# Altium schematic sheet FPGA.SchDoc
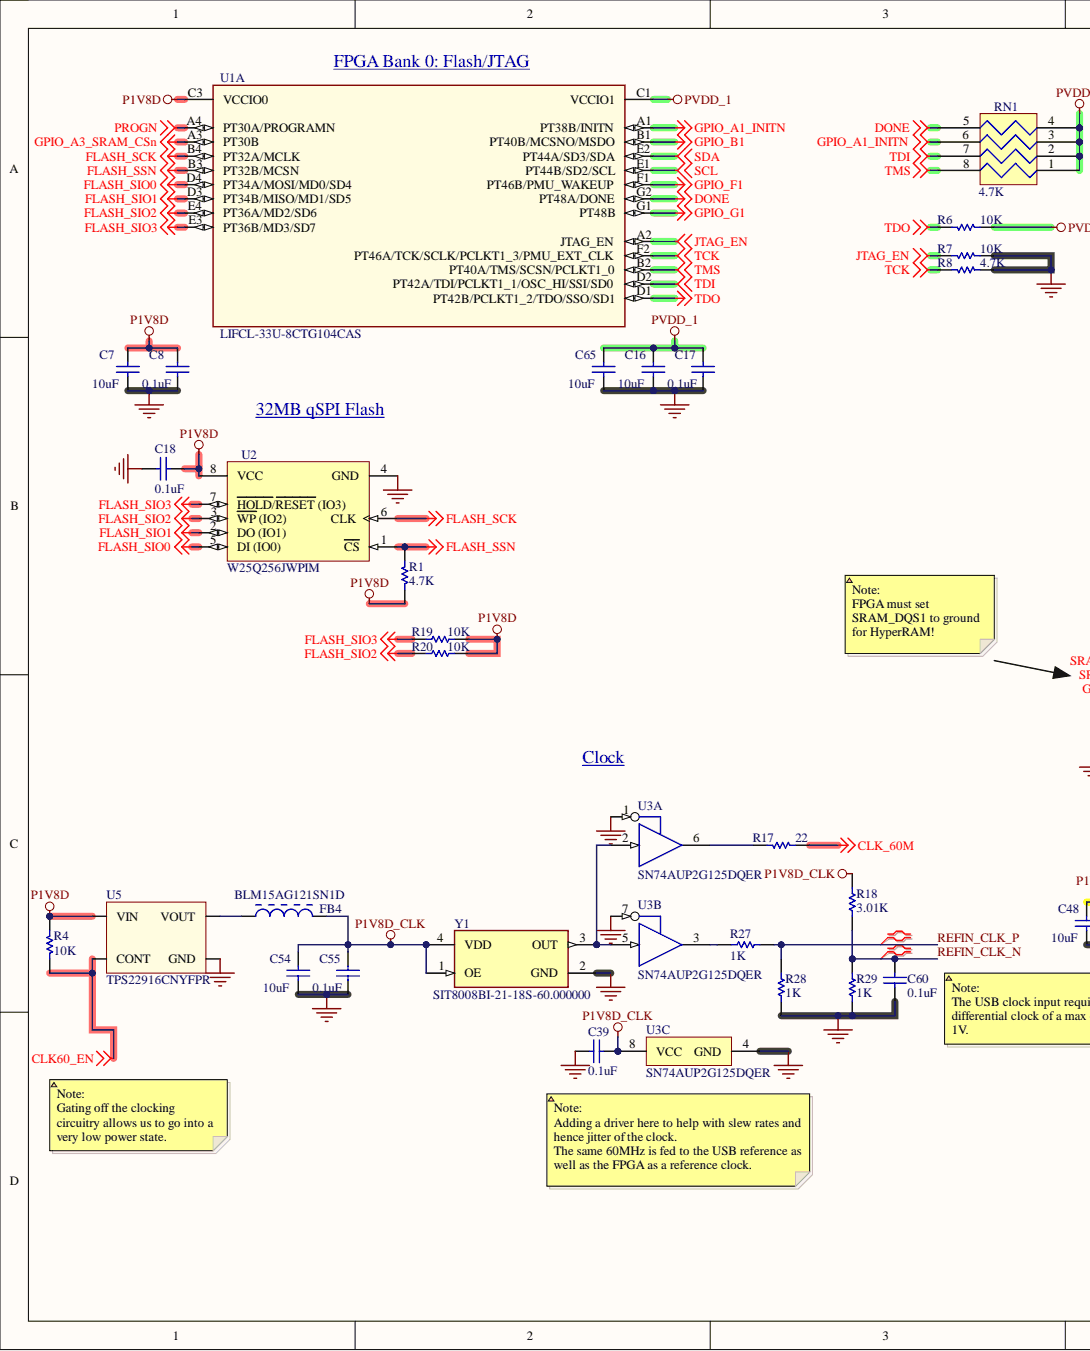
[diagram p0: FPGA.SchDoc, left]
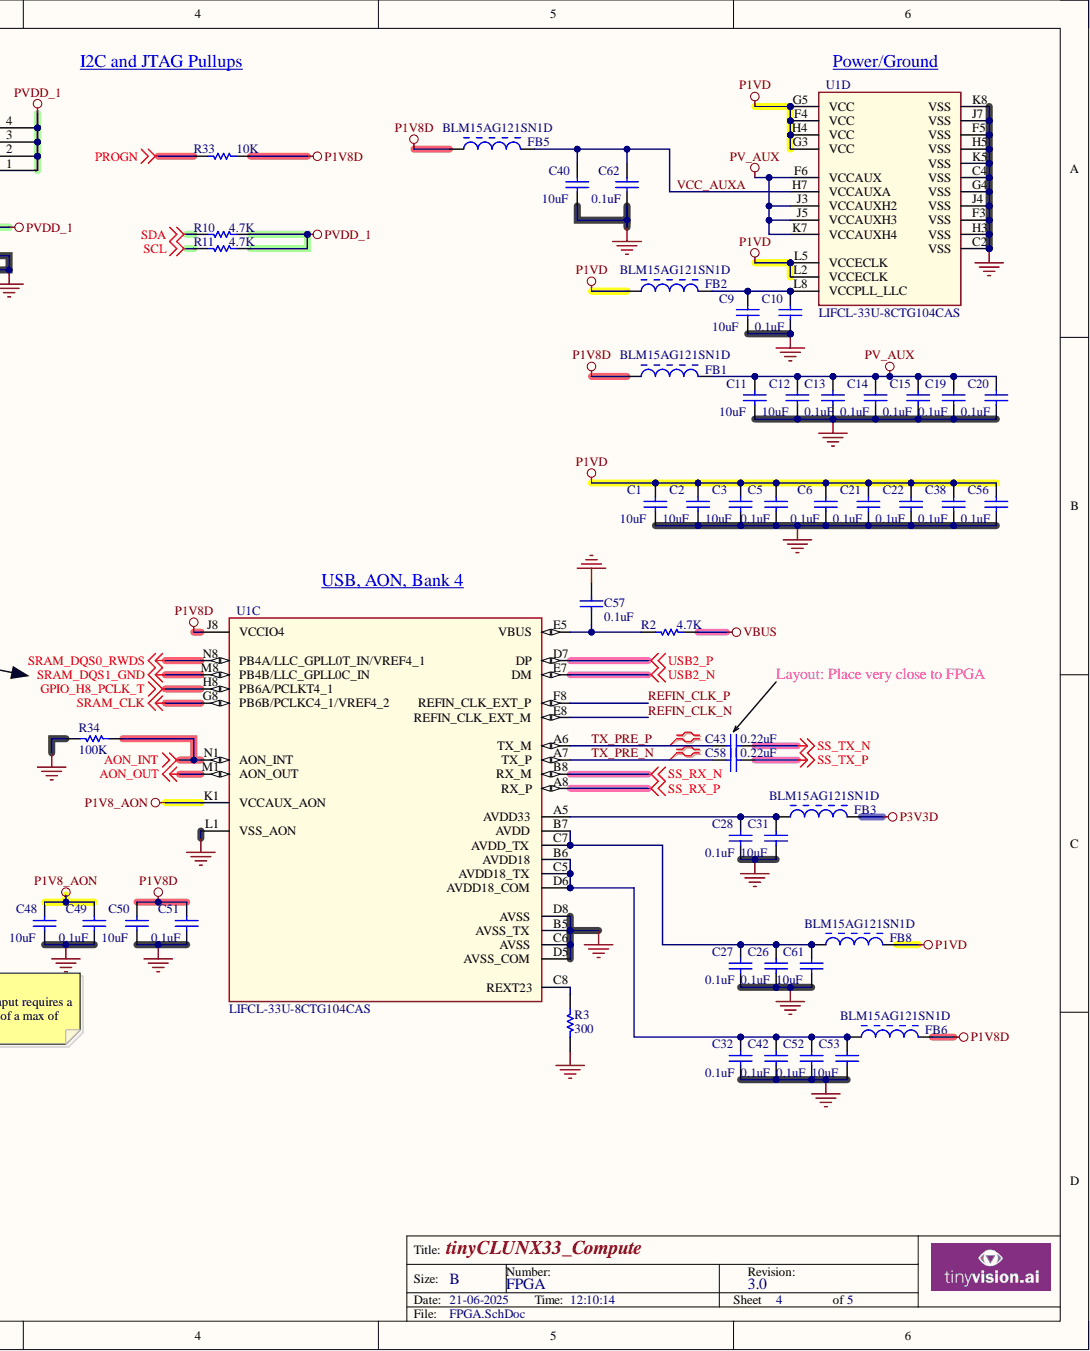
[diagram p1: FPGA.SchDoc, right]

## Parts
C1  10uF  [CAPC1005X55USR]
C2  10uF  [CAPC1005X55USR]
C3  10uF  [CAPC1005X55USR]
C5  0.1uF  [CAPC0603X33N]
C6  0.1uF  [CAPC0603X33N]
C7  10uF  [CAPC1005X55USR]
C8  0.1uF  [CAPC0603X33N]
C9  10uF  [CAPC1005X55USR]
C10  0.1uF  [CAPC0603X33N]
C11  10uF  [CAPC1005X55USR]
C12  10uF  [CAPC1005X55USR]
C13  0.1uF  [CAPC0603X33N]
C14  0.1uF  [CAPC0603X33N]
C15  0.1uF  [CAPC0603X33N]
C16  10uF  [CAPC1005X55USR]
C17  0.1uF  [CAPC0603X33N]
C18  0.1uF  [CAPC0603X33N]
C19  0.1uF  [CAPC0603X33N]
C20  0.1uF  [CAPC0603X33N]
C21  0.1uF  [CAPC0603X33N]
C22  0.1uF  [CAPC0603X33N]
C26  0.1uF  [CAPC0603X33N]
C27  0.1uF  [CAPC0603X33N]
C28  0.1uF  [CAPC0603X33N]
C31  10uF  [CAPC1005X55USR]
C32  0.1uF  [CAPC0603X33N]
C38  0.1uF  [CAPC0603X33N]
C39  0.1uF  [CAPC1005X55USR]
C40  10uF  [CAPC1005X55USR]
C42  0.1uF  [CAPC0603X33N]
C43  0.22uF  [CAPC0603X33N]
C48  10uF  [CAPC1005X55USR]
C49  0.1uF  [CAPC0603X33N]
C50  10uF  [CAPC1005X55USR]
C51  0.1uF  [CAPC0603X33N]
C52  0.1uF  [CAPC0603X33N]
C53  10uF  [CAPC1005X55USR]
C54  10uF  [CAPC1005X55USR]
C55  0.1uF  [CAPC0603X33N]
C56  0.1uF  [CAPC0603X33N]
C57  0.1uF  [CAPC0603X33N]
C58  0.22uF  [CAPC0603X33N]
C60  0.1uF  [CAPC0603X33N]
C61  10uF  [CAPC1005X55USR]
C62  0.1uF  [CAPC0603X33N]
C65  10uF  [CAPC1005X55USR]
FB1  BLM15AG121SN1D  [0402_FB]
FB2  BLM15AG121SN1D  [0402_FB]
FB3  BLM15AG121SN1D  [0402_FB]
FB4  BLM15AG121SN1D  [0402_FB]
FB5  BLM15AG121SN1D  [0402_FB]
FB6  BLM15AG121SN1D  [0402_FB]
FB8  BLM15AG121SN1D  [0402_FB]
R1  4.7K  [RESC1005X40USR]
R2  4.7K  [RESC1005X40USR]
R3  300  [RESC1005X40USR]
R4  10K  [RESC1005X40USR]
R6  10K  [RESC1005X40USR]
R7  10K  [RESC1005X40USR]
R8  4.7K  [RESC1005X40USR]
R10  4.7K  [RESC1005X40USR]
R11  4.7K  [RESC1005X40USR]
R17  22  [RESC1005X40USR]
R18  3.01K  [RESC1005X40USR]
R19  10K  [RESC1005X40USR]
R20  10K  [RESC1005X40USR]
R27  1K  [RESC1005X40USR]
R28  1K  [RESC1005X40USR]
R29  1K  [RESC1005X40USR]
R33  10K  [RESC1005X40USR]
R34  100K  [RESC1005X40USR]
RN1  4.7K  [RESCAXS50P100X200X45-8NA]
U1  LIFCL-33U-8CTG104CAS  [BGA104C65P8X13_550X850X100]  (parts A,C,D)
U2  W25Q256JWPIM  [WSON-8(6X5mm)]
U3  SN74AUP2G125DQER  [DQE0008A_V]  (parts A,B,C)
U5  TPS22916CNYFPR  [WCSP4D]
Y1  SIT8008BI-21-18S-60.000000  [XTAL_32X25X08_SIT8008A]

## Nets
AON_INT: R34.1 U1.N1(AON_INT)
AON_OUT: U1.M1(AON_OUT)
CLK60_EN: R4.1 U5.B2(CONT)
CLK_60M: R17.1
DONE: RN1.5 U1.G2(PT48A/DONE)
FLASH_SCK: U1.B4(PT32A/MCLK) U2.6(CLK)
FLASH_SIO0: U1.D4(PT34A/MOSI/MD0/SD4) U2.5(DI_(IO0))
FLASH_SIO1: U1.D3(PT34B/MISO/MD1/SD5) U2.2(DO_(IO1))
FLASH_SIO2: R20.2 U1.E4(PT36A/MD2/SD6) U2.3(WP_(IO2))
FLASH_SIO3: R19.2 U1.E3(PT36B/MD3/SD7) U2.7(HOLD/RESET_(IO3))
FLASH_SSN: R1.2 U1.B3(PT32B/MCSN) U2.1(CS)
GND: C1.1 C2.1 C3.1 C5.1 C6.1 C7.1 C8.1 C9.1 C10.1 C11.1 C12.1 C13.1 C14.1 C15.1 C16.1 C17.1 C18.1 C19.1 C20.1 C21.1 C22.1 C26.1 C27.1 C28.1 C31.1 C32.1 C38.1 C39.2 C40.1 C42.1 C48.1 C49.1 C50.1 C51.1 C52.1 C53.1 C54.1 C55.1 C56.1 C57.1 C60.1 C61.1 C62.1 C65.1 R3.1 R7.1 R8.2 R28.2 R29.2 R34.2 U1.B5(AVSS_TX) U1.C2(VSS) U1.C4(VSS) U1.C6(AVSS) U1.D5(AVSS_COM) U1.D8(AVSS) U1.F3(VSS) U1.F5(VSS) U1.G4(VSS) U1.H3(VSS) U1.H5(VSS) U1.J4(VSS) U1.J7(VSS) U1.K5(VSS) U1.K8(VSS) U1.L1(VSS_AON) U2.4(GND) U3.1 U3.4(GND) U3.7 U5.B1(GND) Y1.2(GND)
GPIO_A1_INITN: RN1.6 U1.A1(PT38B/INITN)
GPIO_A3_SRAM_CSn: U1.A3(PT30B)
GPIO_B1: U1.B1(PT40B/MCSNO/MSDO)
GPIO_F1: U1.F1(PT46B/PMU_WAKEUP)
GPIO_G1: U1.G1(PT48B)
GPIO_H8_PCLK_T: U1.H8(PB6A/PCLKT4_1)
JTAG_EN: R7.2 U1.A2(JTAG_EN)
P1V8_AON: C48.2 C49.2 U1.K1(VCCAUX_AON)
P1V8D: C7.2 C8.2 C18.2 C50.2 C51.2 FB1.1 FB5.1 FB6.2 R1.1 R4.2 R19.1 R20.1 R33.1 U1.C3(VCCIO0) U1.J8(VCCIO4) U2.8(VCC) U5.A2(VIN)
P1V8D_CLK: C39.1 C54.2 C55.2 FB4.2 R18.1 U3.8(VCC) Y1.1(OE) Y1.4(VDD)
P1VD: C1.2 C2.2 C3.2 C5.2 C6.2 C21.2 C22.2 C38.2 C56.2 FB2.1 FB8.2 U1.F4(VCC) U1.G3(VCC) U1.G5(VCC) U1.H4(VCC) U1.L2(VCCECLK) U1.L5(VCCECLK)
P3V3D: FB3.2
PROGN: R33.2 U1.A4(PT30A/PROGRAMN)
PV_AUX: C11.2 C12.2 C13.2 C14.2 C15.2 C19.2 C20.2 FB1.2 U1.F6(VCCAUX) U1.J3(VCCAUXH2) U1.J5(VCCAUXH3) U1.K7(VCCAUXH4)
PVDD_1: C16.2 C17.2 C65.2 R6.1 R10.2 R11.2 RN1.1 RN1.2 RN1.3 RN1.4 U1.C1(VCCIO1)
SCL: R11.1 U1.E1(PT44B/SD2/SCL)
SDA: R10.1 U1.E2(PT44A/SD3/SDA)
SRAM_CLK: U1.G8(PB6B/PCLKC4_1/VREF4_2)
SRAM_DQS0_RWDS: U1.N8(PB4A/LLC_GPLL0T_IN/VREF4_1)
SRAM_DQS1_GND: U1.M8(PB4B/LLC_GPLL0C_IN)
SS_RX_N: U1.B8(RX_M)
SS_RX_P: U1.A8(RX_P)
SS_TX_N: C43.1
SS_TX_P: C58.1
TCK: R8.1 U1.F2(PT46A/TCK/SCLK/PCLKT1_3/PMU_EXT_CLK)
TDI: RN1.7 U1.D2(PT42A/TDI/PCLKT1_1/OSC_HI/SSI/SD0)
TDO: R6.2 U1.D1(PT42B/PCLKT1_2/TDO/SSO/SD1)
TMS: RN1.8 U1.B2(PT40A/TMS/SCSN/PCLKT1_0)
USB2_N: U1.E7(DM)
USB2_P: U1.D7(DP)
VBUS: R2.2
REFIN_CLK_N: C60.2 R18.2 R29.1 U1.E8(REFIN_CLK_EXT_M)
REFIN_CLK_P: R27.2 R28.1 U1.F8(REFIN_CLK_EXT_P)
TX_PRE_N: C58.2 U1.A7(TX_P)
TX_PRE_P: C43.2 U1.A6(TX_M)
VCC_AUXA: C40.2 C62.2 FB5.2 U1.H7(VCCAUXA)
NetC9_2: C9.2 C10.2 FB2.2 U1.L8(VCCPLL_LLC)
NetC26_2: C26.2 C27.2 C61.2 FB8.1 U1.B7(AVDD) U1.C7(AVDD_TX)
NetC28_2: C28.2 C31.2 FB3.1 U1.A5(AVDD33)
NetC32_2: C32.2 C42.2 C52.2 C53.2 FB6.1 U1.B6(AVDD18) U1.C5(AVDD18_TX) U1.D6(AVDD18_COM)
NetC57_2: C57.2 R2.1 U1.E5(VBUS)
NetFB4_1: FB4.1 U5.A1(VOUT)
NetR3_2: R3.2 U1.C8(REXT23)
NetR17_2: R17.2 U3.6
NetR27_1: R27.1 U3.3
NetU3_2: U3.2 U3.5 Y1.3(OUT)

## Library data of the parts
C1-C3 C7 C9 C11 C12 C16 C31 C40 C48 C50 C53 C54 C61 C65 = Cap_10uF_X5R_20%_10V_0402: CAP CER 10UF 10V X5R 0402; Manufacturer: Samsung Electro-Mechanics; Manufacturer Part Number: CL05A106MP5NUNC; Part #: E-CAP-042; Component Kind: Standard; ComponentLink1Description: New link; Height: 0.55mm; Package: 0402; Supplier 1: Digi-Key; Supplier Part Number 1: 1276-1450-1-ND; value: 10uF; Library Name: tinyCLUNX33_Compute.SCHLIB
C5 C6 C8 C10 C13-C15 C17-C22 C26-C28 C32 C38 C42 C49 C51 C52 C55-C57 C60 C62 = CAP CER 0.1UF 10V X7S 0201: CAP CER 0.1UF 10V X5R 0201; Manufacturer: Murata Electronics; Manufacturer Part Number: GRM033R61A104KE15D; Component Kind: Standard; Height: 0.33mm; Library Name: Smart Camera v2.SCHLIB; Package: 0201; Supplier 1: Digi-Key; Supplier Part Number 1: 490-5881-1-ND; value: 0.1uF
C39 = CAP CER 0.1UF 16V X7R 0402: Manufacturer: TDK Corporation; Manufacturer Part Number: CGA2B1X7R1C104K050BC; Component Kind: Standard; Description: CAP CER 0.1UF 16V X7R 0402; Height: 0.55mm; Library Name: NX Module baseboard.SCHLIB; Package: 0402; Supplier 1: Digi-Key; Supplier Part Number 1: 445-5613-2-ND; value: 0.1uF
C43 C58 = CAP CER 0.22UF 10V X5R 0201: Manufacturer: TDK Corporation; Manufacturer Part Number: C0603X5R1A224K030BB; Component Kind: Standard; Description: CAP CER 0.22UF 10V X5R 0201; Height: 0.33mm; Library Name: MainLIB.SCHLIB; Package: 0201; Supplier 1: Digi-Key; Supplier Part Number 1: 445-7320-2-ND; value: 0.22uF
FB1-FB6 FB8 = MURA-FB-2: FERRITE BEAD 120 OHM 0402 1LN; Manufacturer: Murata Electronics; Value: 120Ohms; Component Kind: Standard; ComponentLink1Description: New link; Height: 0.55mm; Library Name: Smart Camera.SCHLIB; Manufacturer Part Number: BLM15AG121SN1D; Package: 0402; Supplier 1: Digi-Key; Supplier Part Number 1: 490-1005-1-ND
R1 R2 R8 R10 R11 = RES 4.7K OHM 1% 1/20W 0201: RES 4.7K OHM 1% 1/16W 0402; Manufacturer: Yageo; Manufacturer Part Number: RC0402FR-074K7L; Component Kind: Standard; Height: 0.4mm; Library Name: Smart Camera v2.SCHLIB; Package: 0402; Supplier 1: Digi-Key; Supplier Part Number 1: 311-4.7KLRCT-ND; value: 4.7K
R3 = RES 4.7K OHM 1% 1/20W 0201: RES 300 OHM 1% 1/16W 0402; Manufacturer: Yageo; Manufacturer Part Number: RC0402FR-07300RL; Component Kind: Standard; Height: 0.4mm; Library Name: Smart Camera v2.SCHLIB; Package: 0402; Supplier 1: Digi-Key; Supplier Part Number 1: 311-300LRCT-ND; value: 300
R4 R6 R7 R19 R20 R33 = Res_10K_1%_0402: RES 10K OHM 1% 1/16W 0402; Manufacturer: Yageo; Manufacturer Part Number: RC0402FR-0710KL; Component Kind: Standard; Height: 0.4mm; Package: 0402; Supplier 1: Digi-Key; Supplier Part Number 1: 311-10.0KLRCT-ND; value: 10K; Library Name: MCD_Temp_LBE.SchLib
R17 = RES 22 OHM 1% 1/16W 0402: Manufacturer: Yageo; Manufacturer Part Number: RC0402FR-0722RL; Component Kind: Standard; Description: RES 22 OHM 1% 1/16W 0402; Height: 0.40mm; Library Name: NX Module baseboard.SCHLIB; Package: 0402; Supplier 1: Digi-Key; Supplier Part Number 1: 311-22.0LRCT-ND; value: 22
R18 = RES 3.01K OHM 1% 1/16W 0402: Manufacturer: Yageo; Manufacturer Part Number: RC0402FR-073K01L; Component Kind: Standard; Description: RES 3.01K OHM 1% 1/16W 0402; Height: 0.4mm; Library Name: MainLIB.SCHLIB; Package: 0402; Supplier 1: Digi-Key; Supplier Part Number 1: 311-3.01KLRCT-ND; value: 3.01K
R27-R29 = RES 1K OHM 1% 1/16W 0402: RES SMD 1K OHM 1% 1/16W 0402; Manufacturer: YAGEO; Manufacturer Part Number: RC0402FR-071KL; Component Kind: Standard; Height: 0.4mm; Package: 0402; Supplier 1: Digi-Key; Supplier Part Number 1: 311-1.00KLRCT-ND; value: 1K; Library Name: Tiger_Ti180.SCHLIB
R34 = Res_10K_1%_0402: RES 100K OHM 1% 1/16W 0402; Manufacturer: Yageo; Manufacturer Part Number: RC0402FR-07100KL; Component Kind: Standard; Height: 0.4mm; Package: 0402; Supplier 1: Digi-Key; Supplier Part Number 1: 311-100KLRCT-ND; value: 100K; Library Name: MCD_Temp_LBE.SchLib
RN1 = RES ARRAY 4 RES 47K OHM 0804: Res Thick Film Array 4.7K Ohm 5% ±200ppm/°C ISOL 8-Pin 0804(4 X 0402) Convex SMD Automotive T/R; Manufacturer: Panasonic; Manufacturer Part Number: EXB-28V472JX; Circuit Type: Isolated; Number of Contacts: 8; Number of Elements: 4; Power Dissipation: 63mW; Power Per Element: 62.5mW; Voltage Rating: 50V; Voltage Rating (DC): 100V; Case Code (Imperial): 0402; Case Code (Metric): 1005; Case/Package: 0804; Category: Resistor Arrays; Component Kind: Standard; ComponentLink1Description: https://datasheet.ciiva.com/46387/1480003-46387949.pdf?src-supplier=Element14; ComponentLink1URL: https://datasheet.ciiva.com/46387/1480003-46387949.pdf?src-supplier=Element14; ComponentLink2Description: https://datasheet.ciiva.com/46376/1480003-46376265.pdf?src-supplier=Element14; ComponentLink2URL: https://datasheet.ciiva.com/46376/1480003-46376265.pdf?src-supplier=Element14; ComponentLink3Description: https://datasheet.ciiva.com/28418/1790599-28418558.pdf?src-supplier=Element14; ComponentLink3URL: https://datasheet.ciiva.com/28418/1790599-28418558.pdf?src-supplier=Element14; ComponentLink4Description: https://datasheet.ciiva.com/28636/1790599-28636885.pdf?src-supplier=Element14; ComponentLink4URL: https://datasheet.ciiva.com/28636/1790599-28636885.pdf?src-supplier=Element14; ComponentLink5Description: https://datasheet.ciiva.com/30209/aoc0000ce1-30209125.pdf?src-supplier=Onlinecomponents.com; ComponentLink5URL: https://datasheet.ciiva.com/30209/aoc0000ce1-30209125.pdf?src-supplier=Onlinecomponents.com; Depth: 1mm; Height: 0.35mm; Lead Free: Lead Free; Length: 2mm; Library Name: NX Module.SCHLIB; Max Operating Temperature: 125°C; Military Standard: Not; Min Operating Temperature: -55°C; Mounting Technology: Surface Mount; Number of Resistors: 4; Package Quantity: 1; Packaging: Tape and Reel; Pins: 8; Pricing: 1=0.10000, 10=0.08100, 25=0.05640, 50=0.04380, 100=0.03130, 250=0.02444, 500=0.01942, 1000=0.01378, 5000=0.01065 (USD); Radiation Hardening: No; Reach SVHC Compliant: Unknown; Resistance Tolerance: 5%; RoHS: Yes; RoHS Compliant: Yes; Series: EXB; Stock: 1570078; Supplier 1: Digi-Key; Supplier Part Number 1: Y7472CT-ND; Technology: THICK FILM; Temperature Coefficient: 200ppm/°C; Terminal Pitch: 0.5mm; Termination: Convex; Tolerance: 5%; Value: 4.7K; Width: 1mm
U1 = LIFCL-33U-8CTG104CAS: Manufacturer: LATTICE; Component Kind: Standard; Library Name: NX Module.SCHLIB; Manufacturer Part Number: LIFCL-33U-8CTG104CAS
U2 = WINB-W25Q128FVSIG-SOIC-8: IC FLASH 256MBIT SPI/QUAD 8WSON; Manufacturer: Winbond; Category: Memory; Component Kind: Standard; Library Name: NX Module.SCHLIB; Manufacturer Part Number: W25Q256JWPIM; Pricing: 100=2.52 (USD); RoHS: Yes; Supplier 1: Digi-Key; Supplier Part Number 1: 256-W25Q256JWPIM-ND
U3 = CMP-0859-00754-3: Low-Power Dual Bus Buffer Gate With 3-State Outputs, DQE0008A, LARGE T&R; Manufacturer: Texas Instruments; 3-State Output: Yes; Bits(#): 2; F @ Nom Voltage(Max)(Mhz): 100; ICC @ Nom Voltage(Max)(mA): 0.0009; Input Type: CMOS; Logic: True; Output Drive (IOL/IOH)(Max)(mA): 20/-20; Rating: Catalog; Schmitt Trigger: No; Sub-Family: Non-Inverting Buffer/Driver; Technology Family: AUP; tpd @ Nom Voltage(Max)(ns): 37.9,30.2,17.2,13.0,8.3,6.5; VCC(Max)(V): 3.6; VCC(Min)(V): 0.8; Voltage(Nom)(V): 0.8,1.2,1.5,1.8,2.5,3.3; Component Kind: Standard; DatasheetVersion: SCES688D; Generic Part Number: SN74AUP2G125; Library Name: Altium Content Vault; Operating Temperature Range(C): -40 to 85; Output Type: CMOS; PackageReference: DQE0008A
U5 = TCK106AG: IC PWR SWITCH P-CHAN 1:1 4WCSPD; Manufacturer: Texas Instruments; 1pc cost: $0.47; 100pc cost: $0.2349; Built_By: EMA_UL_Team; Number_devices_in_Package: 1; Component Kind: Standard; Height: 0.55mm; Library Name: tinyAI.SCHLIB; Manufacturer Part Number: TPS22916CNYFPR; RefDes: RefDes; Source_Package: TPS22916CNYFPR; Supplier 1: Digi-Key; Supplier Part Number 1: TCK106AGLFCT-ND; Type: DEV; Value: Value; Vendor: Texas Instruments; Copyright: Copyright (C) 2018 Accelerated Designs. All rights reserved
Y1 = OSC_SIT8008AC-23-33E-60.000000: MEMS OSC XO 59.0000MHZ H/LV-CMOS; Manufacturer: SiTime; Component Kind: Standard; Library Name: MainLIB.SCHLIB; Manufacturer Part Number: SIT8008AC-23-33E-60.000000; Pricing 1: 1=1.26000, 10=1.11000, 50=1.03800, 100=0.91730, 500=0.86898, 1000=0.72415, 2500=0.70002, 5000=0.67588, 10000=0.65174 (USD); RoHS: No; Supplier 1: Digikey; Supplier Part Number 1: 1473-SIT8008AC-23-33E-60.000000-ND
